# Altium schematic sheet nrf51822_dev_dongle_interfaces.SchDoc
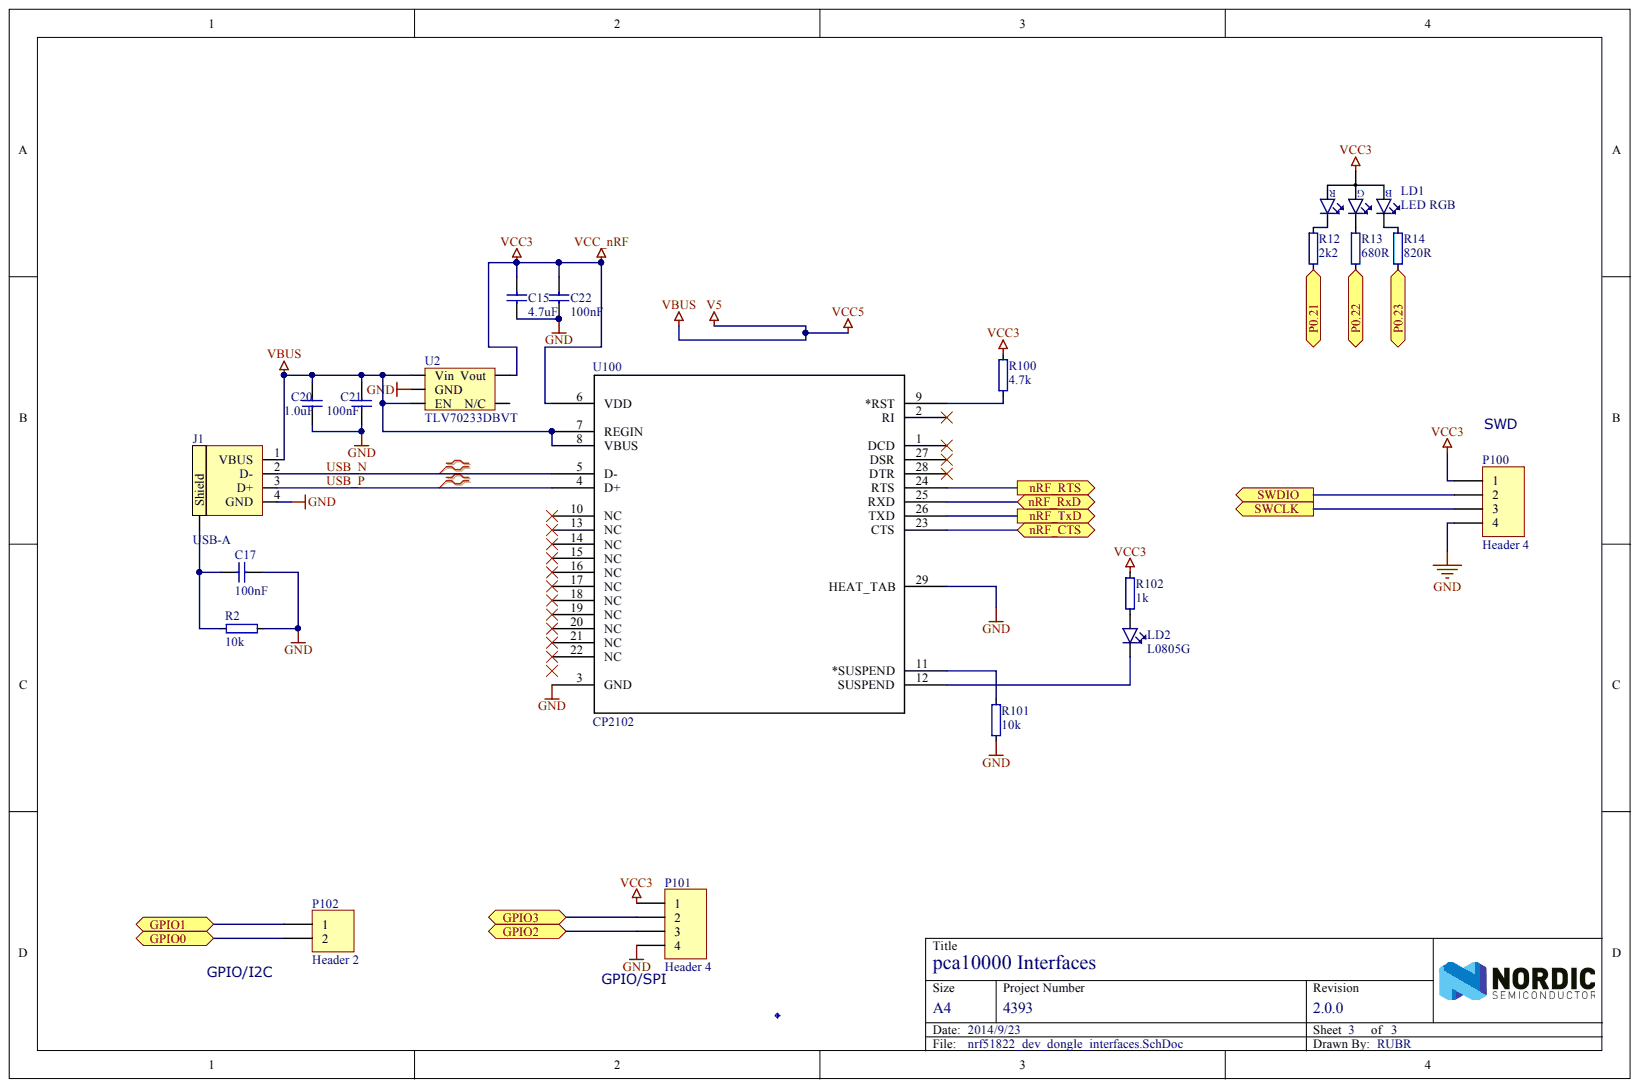
[diagram p0: nrf51822_dev_dongle_interfaces.SchDoc]

## Parts
C15  4.7uF  [0603]
C17  100nF  [0402]
C20  1.0uF  [0603]
C21  100nF  [0402]
C22  100nF  [0402]
Fid1  Fiducial mark  [Fiducial]  (value not drawn)
J1  USB-A  [USB-PCB-A-SMD]
LD1  LED RGB  [SML-LX0404SIUPGUSB]
LD2  L0805G  [0805]
P100  Header 4  [HDR1X4]
P101  Header 4  [HDR1X4]
P102  Header 2  [HDR1X2]
R2  10k  [0402]
R12  2k2  [0402]
R13  680R  [0402]
R14  820R  [0402]
R100  4.7k  [0402]
R101  10k  [0402]
R102  1k  [0402]
U2  TLV70233DBVT  [SOT-23-5]
U100  CP2102  [QFN28N5X5-0p5P]

## Ports
GPIO0, GPIO1, GPIO2, GPIO3, NRF_CTS, NRF_RTS, NRF_RXD, NRF_TXD, P0.21, P0.22, P0.23, SWCLK, SWDIO

## Nets
GND: C15.1 C17.1 C20.1 C21.2 C22.2 J1.4(GND) P100.4 P101.4 R2.1 R101.2 U2.2(GND) U100.3(GND) U100.29(HEAT_TAB)
V5: C20.2 C21.1 J1.1(VBUS) U2.1(Vin) U2.3(EN) U100.7(REGIN) U100.8(VBUS)
VCC3: C15.2 C22.1 LD1.4 P100.1 P101.1 R100.1 R102.2 U2.5(Vout) U100.6(VDD)
GPIO0: P102.2 ; port GPIO0
GPIO1: P102.1 ; port GPIO1
GPIO2: P101.3 ; port GPIO2
GPIO3: P101.2 ; port GPIO3
P0.21: R12.1 ; port P0.21
P0.22: R13.1 ; port P0.22
P0.23: R14.1 ; port P0.23
SWCLK: P100.3 ; port SWCLK
SWDIO: P100.2 ; port SWDIO
USB_N: J1.2(D-) U100.5(D-)
USB_P: J1.3(D+) U100.4(D+)
NetC17_2: C17.2 J1.0(Shield) R2.2
NetLD1_1: LD1.1 R12.2
NetLD1_2: LD1.2 R13.2
NetLD1_3: LD1.3 R14.2
NetLD2_1: LD2.1 U100.12(SUSPEND)
NetLD2_2: LD2.2 R102.1
NetR100_2: R100.2 U100.9(*RST)
NetR101_1: R101.1 U100.11(*SUSPEND)
NetU100_23: U100.23(CTS) ; port NRF_CTS
NetU100_24: U100.24(RTS) ; port NRF_RTS
NetU100_25: U100.25(RXD) ; port NRF_RXD
NetU100_26: U100.26(TXD) ; port NRF_TXD

Not connected: U2 4(N/C); U100 1(DCD) 2(RI) 10(NC) 13(NC) 14(NC) 15(NC) 16(NC) 17(NC) 18(NC) 19(NC) 20(NC) 21(NC) 22(NC) 27(DSR) 28(DTR)

## Library data of the parts
C15 C20 = C0603-1?: Capacitor, X5R, ?0%; Artikkelnr Noca: 20039205; Database Table Name: Capacitors$; Note: 10V; Component Kind: Standard; LatestRevisionDate: 2009-11-23; Library Name: Nordic Passive DB.DbLib; Part Number: C0603-1?; Pin Count: 2; Published: 2009-05-08; Publisher: Nordic Semiconductor
C17 C21 C22 = C0402-100n: Capacitor, X7R, ?0%; Artikkelnr Noca: 20039200; Database Table Name: Capacitors$; Note: 16V; Component Kind: Standard; LatestRevisionDate: 2009-11-23; Library Name: Nordic Passive DB.DbLib; Part Number: C0402-100n; Pin Count: 2; Published: 2009-05-08; Value: 100nF; Publisher: Nordic Semiconductor
Fid1 = Fid-Mark: Artikkelnr Noca: N.A.; Database Table Name: Connectors$; Component Kind: Standard; Description: Fiducial Mark; LatestRevisionDate: 2009-12-12; Library Name: Nordic Passive DB.DbLib; Part Number: Fid-Mark; Pin Count: 1; Published: 2009-12-12; Publisher: Nordic Semiconductor
J1 = Molex USB-A-SMT_w/shield: Molex USB-A-SMT; 2nd source part number: 538-48037-1000; Manufacturer Part Number: 48037-1000; Artikkelnr Noca: 55079008; Database Table Name: Connectors$; Note: MOLEX - 48037-1000; 2nd source Supplier: Mouser; Component Kind: Standard; Datasheet: http://www.molex.com/pdm_docs/sd/480371000_sd.pdf; LatestRevisionDate: 2012-11-21; Library Name: Nordic Passive DB.DbLib; Manufacturer: Molex; Part Number: Molex USB-A-SMT_w/shield; Published: 2012-11-21; Supplier: Digi-Key; Supplier part number: WM17118-ND; Value: USB-A; Publisher: Nordic Semiconductor
LD1 = (no symbol name): RGB LED 1.00 mm x 1.00 mm SMD; 2nd source part number: 211-8843; Manufacturer: Lumex; Artikkelnr Noca: N.A.; 2nd source Supplier: Farnell; Component Kind: Standard; Datasheet: http://media.digikey.com/pdf/Data%20Sheets/Lumex%20PDFs/SML-LX0404SIUPGUSB.pdf; LatestRevisionDate: 2012-10-08; Manufacturer Part Number: SML-LX0404SIUPGUSB; Part Number: SML-LX0404SIUPGUSB; Pin Count: 4; Published: 2012-10-08; Supplier: Digi-Key; Supplier part number: 67-2125-1-ND; Value: LED RGB; Library Name: ohtcom.SCHLIB; Publisher: Nordic Semiconductor
LD2 = (no symbol name): LED, SMD, 0805, GREEN; Manufacturer: ROHM; Manufacturer Part Number: SML-210PTT86; Part Number: SML-210PT; Artikkelnr Noca: 13019123; Note: 4 mcd @ 20 mA; Component Kind: Standard; Datasheet: http://no.farnell.com/rohm/sml-210dtt86/led-smd-0805-orange/dp/1685051; LatestRevisionDate: 2009-09-03; Library Name: pca10010.IntLib; Pin Count: 2; Published: 2009-09-03; Value: L0805G; Publisher: Nordic Semiconductor
P100 P101 = Header 4: Header, 4-Pin; Component Kind: Standard; LatestRevisionDate: 17-Jul-2002; LatestRevisionNote: Re-released for DXP Platform.; Library Name: Miscellaneous Connectors.IntLib; Publisher: Altium Limited
P102 = Header 2: Header, 2-Pin; Component Kind: Standard; LatestRevisionDate: 17-Jul-2002; LatestRevisionNote: Re-released for DXP Platform.; Library Name: Miscellaneous Connectors.IntLib; Publisher: Altium Limited
R2 R100 R101 = RES_0420: Resistor, ?%, 0.063W; Part Number: R0402-0R; Artikkelnr Noca: 27049000; Note: Chip Res 5%; Component Kind: Standard; LatestRevisionDate: 2009-11-23; Library Name: pca10000.IntLib; Pin Count: 2; Published: 2009-05-08; Supplier: Farnell; Supplier part number: 146-9661; Publisher: Nordic Semiconductor
  R2 R101: Value: 10k
  R100: Value: 4.7k
R12 = R0402-2k2: Resistor, ?%, 0.063W; Artikkelnr Noca: 27049081; Database Table Name: Resistors$; Note: Chip Res 1%; Component Kind: Standard; LatestRevisionDate: 2009-11-23; Library Name: Nordic Passive DB.DbLib; Part Number: R0402-2k2; Pin Count: 2; Published: 2009-05-08; Supplier: Farnell; Supplier part number: 173-8854; Value: 2k2; Publisher: Nordic Semiconductor
R13 = R0402-680: Resistor, ?%, 0.063W; Artikkelnr Noca: 27049069; Database Table Name: Resistors$; Note: Chip Res 1%; Component Kind: Standard; LatestRevisionDate: 2009-11-23; Library Name: Nordic Passive DB.DbLib; Part Number: R0402-680; Pin Count: 2; Published: 2009-05-08; Supplier: Farnell; Supplier part number: 173-8848; Value: 680R; Publisher: Nordic Semiconductor
R14 = R0402-820: Resistor, ?%, 0.063W; Artikkelnr Noca: 27049071; Database Table Name: Resistors$; Note: Chip Res 1%; Component Kind: Standard; LatestRevisionDate: 2009-11-23; Library Name: Nordic Passive DB.DbLib; Part Number: R0402-820; Pin Count: 2; Published: 2009-05-08; Supplier: Farnell; Supplier part number: 173-8849; Value: 820R; Publisher: Nordic Semiconductor
R102 = (no symbol name): Resistor, ?%, 0.063W; Part Number: R0402-12k; Artikkelnr Noca: 27049099; Note: Chip Res 1%; Component Kind: Standard; LatestRevisionDate: 2009-11-23; Library Name: pca10010.IntLib; Pin Count: 2; Published: 2009-05-08; Supplier: Farnell; Supplier part number: 173-8865; Value: 1k; Publisher: Nordic Semiconductor
U2 = TLV70233DBVT: IC REG LDO 3.3V 300MA SOT23-5; Manufacturer: Texas Instruments; Artikkelnr Noca: 17039215; Database Table Name: Misc IC$; Note: 300-mA, Low-IQ, Low-Dropout Regulator; Component Kind: Standard; Datasheet: http://www.ti.com/lit/ds/symlink/tlv70218.pdf; LatestRevisionDate: 2012-07-06; Library Name: Nordic Active DB.DbLib; Manufacturer Part Number: TLV70233DBVT; Part Number: TLV70233DBVT; Pin Count: 5; Published: 2012-07-06; Supplier: Digi-Key; Supplier part number: 296-27895-1-ND; Value: TLV70233DBVT; Publisher: Nordic Semiconductor
U100 = CP2102: CP2102 USB to UART; PART DESC: SINGLE-CHIP USB TO UART BRIDGE, QFN28, RoHS.; MFG #1: SILICON LABS; Component Kind: Standard; Library Name: pca10010.IntLib; PART NUMBER: CP2102; RefDes: RefDes; Type: DEV; Value: Value
